# Altium schematic sheet 8-User interfaces.schdoc("8-User interfaces")
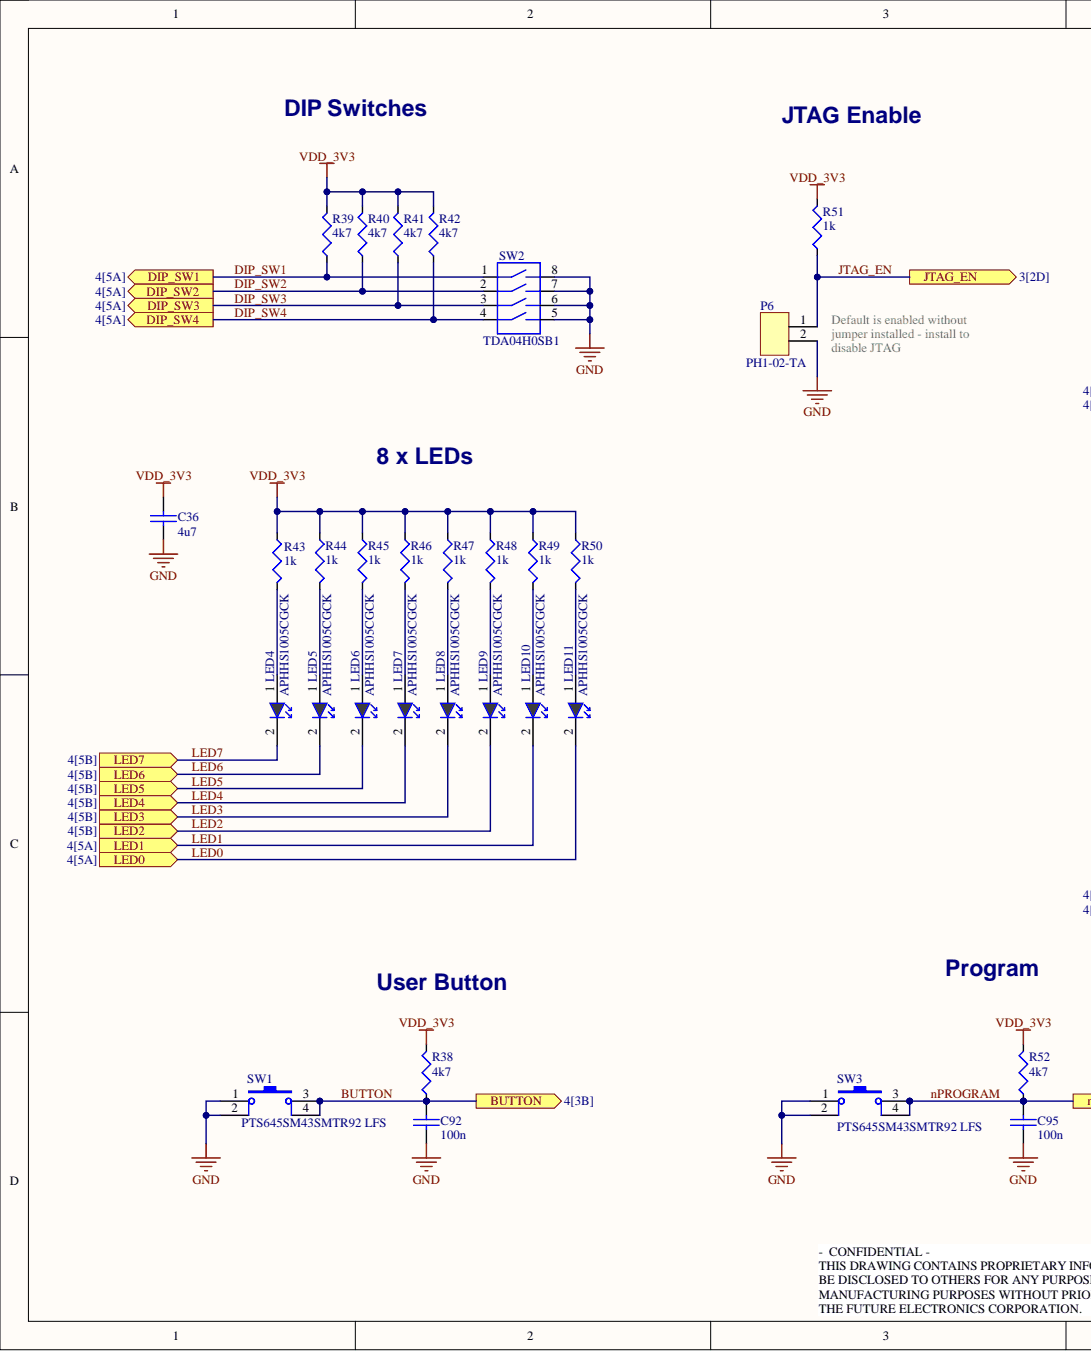
[diagram p0: 8-User interfaces.schdoc, left]
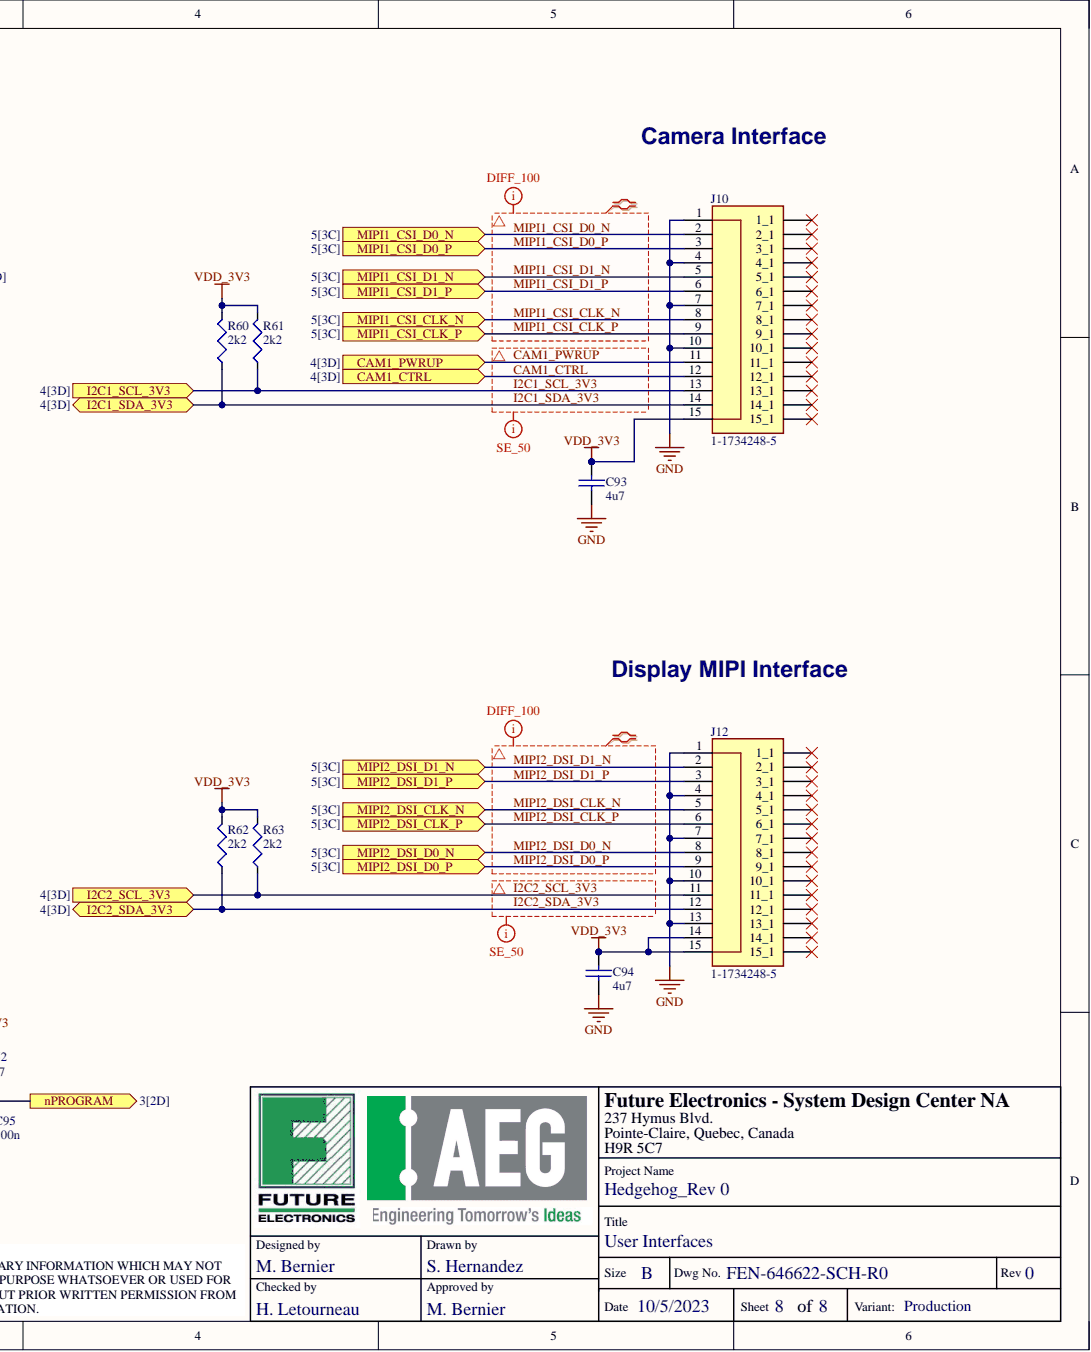
[diagram p1: 8-User interfaces.schdoc, right]

## Parts
C36  value 4u7  [0805]  (hidden comment: C-4.7uF-10V-Cer-0805)
C92  value 100n  [0402]  (hidden comment: C-100nF-50V-Cer-0402)
C93  value 4u7  [0805]  (hidden comment: C-4.7uF-10V-Cer-0805)
C94  value 4u7  [0805]  (hidden comment: C-4.7uF-10V-Cer-0805)
C95  value 100n  [0402]  (hidden comment: C-100nF-50V-Cer-0402)
J10  Future PN (TR): 1-1734248-5  [TE_1-1734248-5]  (hidden comment: J-1-1734248-5)
J12  Future PN (TR): 1-1734248-5  [TE_1-1734248-5]  (hidden comment: J-1-1734248-5)
LED4  Future PN (TR): APHHS1005CGCK  [0402-Kingbright]  (hidden comment: LED-APHHS1005CGCK)
LED5  Future PN (TR): APHHS1005CGCK  [0402-Kingbright]  (hidden comment: LED-APHHS1005CGCK)
LED6  Future PN (TR): APHHS1005CGCK  [0402-Kingbright]  (hidden comment: LED-APHHS1005CGCK)
LED7  Future PN (TR): APHHS1005CGCK  [0402-Kingbright]  (hidden comment: LED-APHHS1005CGCK)
LED8  Future PN (TR): APHHS1005CGCK  [0402-Kingbright]  (hidden comment: LED-APHHS1005CGCK)
LED9  Future PN (TR): APHHS1005CGCK  [0402-Kingbright]  (hidden comment: LED-APHHS1005CGCK)
LED10  Future PN (TR): APHHS1005CGCK  [0402-Kingbright]  (hidden comment: LED-APHHS1005CGCK)
LED11  Future PN (TR): APHHS1005CGCK  [0402-Kingbright]  (hidden comment: LED-APHHS1005CGCK)
P6  P-PH1-02-TA  MFR PN: PH1-02-TA  [HDR100-2PIN-TH]
R38  value 4k7  [0402]  (hidden comment: R-4.7k-63mW-0402)
R39  value 4k7  [0402]  (hidden comment: R-4.7k-63mW-0402)
R40  value 4k7  [0402]  (hidden comment: R-4.7k-63mW-0402)
R41  value 4k7  [0402]  (hidden comment: R-4.7k-63mW-0402)
R42  value 4k7  [0402]  (hidden comment: R-4.7k-63mW-0402)
R43  value 1k  [0402]  (hidden comment: R-1k-63mW-0402)
R44  value 1k  [0402]  (hidden comment: R-1k-63mW-0402)
R45  value 1k  [0402]  (hidden comment: R-1k-63mW-0402)
R46  value 1k  [0402]  (hidden comment: R-1k-63mW-0402)
R47  value 1k  [0402]  (hidden comment: R-1k-63mW-0402)
R48  value 1k  [0402]  (hidden comment: R-1k-63mW-0402)
R49  value 1k  [0402]  (hidden comment: R-1k-63mW-0402)
R50  value 1k  [0402]  (hidden comment: R-1k-63mW-0402)
R51  value 1k  [0402]  (hidden comment: R-1k-63mW-0402)
R52  value 4k7  [0402]  (hidden comment: R-4.7k-63mW-0402)
R60  value 2k2  [0402]  (hidden comment: R-2.2k-63mW-0402)
R61  value 2k2  [0402]  (hidden comment: R-2.2k-63mW-0402)
R62  value 2k2  [0402]  (hidden comment: R-2.2k-63mW-0402)
R63  value 2k2  [0402]  (hidden comment: R-2.2k-63mW-0402)
SW1  SW-PTS645SM43SMTR92 LFS  [SW-MOM-SMD-PTS645]  (value not drawn)
SW2  MFR PN: TDA04H0SB1  [UlMiniDip4]  (hidden comment: SW-TDA04H0SB1)
SW3  SW-PTS645SM43SMTR92 LFS  [SW-MOM-SMD-PTS645]  (value not drawn)

## Ports
BUTTON, CAM1_CTRL, CAM1_PWRUP, DIP_SW1, DIP_SW2, DIP_SW3, DIP_SW4, I2C1_SCL_3V3, I2C1_SDA_3V3, I2C2_SCL_3V3, I2C2_SDA_3V3, JTAG_EN, LED0, LED1, LED2, LED3, LED4, LED5, LED6, LED7, MIPI1_CSI_CLK_N, MIPI1_CSI_CLK_P, MIPI1_CSI_D0_N, MIPI1_CSI_D0_P, MIPI1_CSI_D1_N, MIPI1_CSI_D1_P, MIPI2_DSI_CLK_N, MIPI2_DSI_CLK_P, MIPI2_DSI_D0_N, MIPI2_DSI_D0_P, MIPI2_DSI_D1_N, MIPI2_DSI_D1_P, nPROGRAM

## Nets
GND: C36.2 C92.2 C93.2 C94.2 C95.2 J10.1 J10.4 J10.7 J10.10 J12.1 J12.4 J12.7 J12.10 J12.13 P6.2 SW1.1 SW1.2 SW2.5 SW2.6 SW2.7 SW2.8 SW3.1 SW3.2
VDD_3V3: C36.1 C93.1 C94.1 J10.15 J12.14 J12.15 R38.2 R39.2 R40.2 R41.2 R42.2 R43.1 R44.1 R45.1 R46.1 R47.1 R48.1 R49.1 R50.1 R51.2 R52.2 R60.1 R61.1 R62.1 R63.1
BUTTON: C92.1 R38.1 SW1.3 SW1.4 ; port BUTTON
CAM1_CTRL: J10.12 ; port CAM1_CTRL
CAM1_PWRUP: J10.11 ; port CAM1_PWRUP
DIP_SW1: R39.1 SW2.1 ; port DIP_SW1
DIP_SW2: R40.1 SW2.2 ; port DIP_SW2
DIP_SW3: R41.1 SW2.3 ; port DIP_SW3
DIP_SW4: R42.1 SW2.4 ; port DIP_SW4
I2C1_SCL_3V3: J10.13 R61.2 ; port I2C1_SCL_3V3
I2C1_SDA_3V3: J10.14 R60.2 ; port I2C1_SDA_3V3
I2C2_SCL_3V3: J12.11 R63.2 ; port I2C2_SCL_3V3
I2C2_SDA_3V3: J12.12 R62.2 ; port I2C2_SDA_3V3
JTAG_EN: P6.1 R51.1 ; port JTAG_EN
LED0: LED11.2 ; port LED0
LED1: LED10.2 ; port LED1
LED2: LED9.2 ; port LED2
LED3: LED8.2 ; port LED3
LED4: LED7.2 ; port LED4
LED5: LED6.2 ; port LED5
LED6: LED5.2 ; port LED6
LED7: LED4.2 ; port LED7
MIPI1_CSI_CLK_N: J10.8 ; port MIPI1_CSI_CLK_N
MIPI1_CSI_CLK_P: J10.9 ; port MIPI1_CSI_CLK_P
MIPI1_CSI_D0_N: J10.2 ; port MIPI1_CSI_D0_N
MIPI1_CSI_D0_P: J10.3 ; port MIPI1_CSI_D0_P
MIPI1_CSI_D1_N: J10.5 ; port MIPI1_CSI_D1_N
MIPI1_CSI_D1_P: J10.6 ; port MIPI1_CSI_D1_P
MIPI2_DSI_CLK_N: J12.5 ; port MIPI2_DSI_CLK_N
MIPI2_DSI_CLK_P: J12.6 ; port MIPI2_DSI_CLK_P
MIPI2_DSI_D0_N: J12.8 ; port MIPI2_DSI_D0_N
MIPI2_DSI_D0_P: J12.9 ; port MIPI2_DSI_D0_P
MIPI2_DSI_D1_N: J12.2 ; port MIPI2_DSI_D1_N
MIPI2_DSI_D1_P: J12.3 ; port MIPI2_DSI_D1_P
nPROGRAM: C95.1 R52.1 SW3.3 SW3.4 ; port nPROGRAM
NetLED4_1: LED4.1 R43.2
NetLED5_1: LED5.1 R44.2
NetLED6_1: LED6.1 R45.2
NetLED7_1: LED7.1 R46.2
NetLED8_1: LED8.1 R47.2
NetLED9_1: LED9.1 R48.2
NetLED10_1: LED10.1 R49.2
NetLED11_1: LED11.1 R50.2

Not connected: J10 1_1 2-1(2_1) 3_1 4_1 5_1 6_1 7_1 8_1 9_1 10_1 11_1 12_1 13_1 14_1 15_1; J12 1_1 2-1(2_1) 3_1 4_1 5_1 6_1 7_1 8_1 9_1 10_1 11_1 12_1 13_1 14_1 15_1

## Library data of the parts
C36 C93 C94 = C-4.7uF-10V-Cer-0805: Cap 0805 SM X7R 10V 10%; MFR: TAIYO YUDEN; MFR PN: LMK212B7475KG-T; Future PN (CT): LMK212B7475KGTR-CT; Future PN (TR): LMK212B7475KGTR; Rating: 10V 10%; Component Kind: Standard; ComponentLink1Description: Datasheet; ComponentLink1URL: https://www.yuden.co.jp/productdata/catalog/mlcc01_e.pdf; Library Name: Future_Capacitors_V1.SCHLIB; Verified: R.Brack_2020-Feb-03
C92 C95 = C-100nF-50V-Cer-0402: Cap 0402 SM X7R 50V 10%; MFR: TDK; MFR PN: C1005X7R1H104K050BB; Future PN (CT): 04025C104KATN-CT; Future PN (TR): 04025C104KATN; Rating: 50V 10%; Component Kind: Standard; ComponentLink1Description: Datasheet; ComponentLink1URL: http://images.ihscontent.net/vipimages/VipMasterIC/IC/TDKC/TDKC-S-A0000443042/TDKC-S-A0000443042-1.pdf; Library Name: Future_Capacitors_V1.SCHLIB; Verified: AD042616
J10 J12 = J-1-1734248-5: Connector FPC Vert SM 1mm pitch; MFR: TE; Future PN (CT): TBD; Rating: 1x15, 1mm pitch; VModel: MMDDYY; Component Kind: Standard; ComponentLink1Description: Datasheet; ComponentLink1URL: https://www.te.com/commerce/DocumentDelivery/DDEController?Action=srchrtrv&DocNm=1734248&DocType=Customer+Drawing&DocLang=English; MFR PN: 1-1734248-5; Created by: CZ050922; Library Name: Hedgehog_Rev0.SchLib
LED4-LED11 = LED-APHHS1005CGCK: LED Green AlGaInP 0402 SM 2.1-2.5Vf 30mA 574nm-pk 570nm-d 20mcd; MFR: KINGBRIGHT; Future PN (CT): APHHS1005CGCK-CT; Rating: 2.1Vf 20mA 75mW 570nm 50mcd; Component Kind: Standard; ComponentLink1Description: Datasheet; ComponentLink1URL: http://www.kingbrightusa.com/images/catalog/SPEC/APHHS1005CGCK.pdf; Library Name: Future_Opto_V1.SchLib; MFR PN: APHHS1005CGCK; Value: GREEN; Created by: R.Brack_2020-Mar-02
P6 = P-PH1-02-TA: Connector 1Row Vert TH 1x2 2.54mm Pin Header; MFR: ADAMTECH; Future PN (CT): NSH-02SB-S2-T; Future PN (TR): TBD; VModel: MMDDYY; Component Kind: Standard; ComponentLink1Description: Datasheet; ComponentLink1URL: http://images.ihscontent.net/vipimages/VipMasterIC/IC/ADMT/ADMTS02206/ADMTS02206-1.pdf; Library Name: Future_Connectors_V1.SCHLIB; Rating: 1x2 2.54mm; Verified: AD072915
R38-R42 R52 = R-4.7k-63mW-0402: Res 0402 SM 63mW 1% 100ppm; MFR: YAGEO; MFR PN: RC0402FR-074K7L; Future PN (CT): CR0402-4701FTR-CT; Future PN (TR): CR0402-4701FTR; Rating: 63mW 1%; Component Kind: Standard; ComponentLink1Description: Datasheet; ComponentLink1URL: http://www.yageo.com/documents/recent/PYu-RC0402_51_RoHS_L_6.pdf; Library Name: Future_Resistors_V1.SCHLIB
R43-R51 = R-1k-63mW-0402: Res 0402 SM 63mW 1% 100ppm; MFR: YAGEO; MFR PN: RC0402FR-071KL; Future PN (CT): CR0402-1001FTR-CT; Future PN (TR): CR0402-1001FTR; Rating: 63mW 1%; Component Kind: Standard; ComponentLink1Description: Datasheet; ComponentLink1URL: https://www.yageo.com/upload/media/product/products/datasheet/rchip/PYu-RC_Group_51_RoHS_L_10.pdf; Library Name: Future_Resistors_V1.SCHLIB
R60-R63 = R-2.2k-63mW-0402: Res 0402 SM 63mW 1% 100ppm; MFR: YAGEO; MFR PN: RC0402FR-072K2L; Future PN (CT): CR0402-2201FTR-CT; Future PN (TR): CR0402-2201FTR; Rating: 63mW 1%; Component Kind: Standard; ComponentLink1Description: Datasheet; ComponentLink1URL: https://www.yageo.com/upload/media/product/products/datasheet/rchip/PYu-RC_Group_51_RoHS_L_10.pdf; Library Name: Future_Resistors_V1.SCHLIB
SW1 SW3 = SW-PTS645SM43SMTR92 LFS: Switch Mom SPST-NO 6.0x6.0 SM 12Vdc 50mA; MFR: C&K; Future PN (CT): TBD; Component Kind: Standard; ComponentLink1Description: Datasheet; ComponentLink1URL: https://www.ckswitches.com/media/1471/pts645.pdf; Future PN (TR): TBD; MFR PN: PTS645SM43SMTR92 LFS; Rating: 12Vdc 50mA; Verified: TBD; Library Name: Hedgehog_Rev0.SchLib
SW2 = SW-TDA04H0SB1: Switch Slide 4-SPST UlMiniDip4 SM 24Vdc 25mA 100mOhm; MFR: C&K; Future PN (CT): TDA04H0SK1R-CT; Future PN (TR): TDA04H0SK1R; VModel: MMDDYY; Component Kind: Standard; ComponentLink1Description: Datasheet; ComponentLink1URL: http://www1.futureelectronics.com/doc/C%20&%20K%20COMPONENTS/TDA04H0SB1.pdf; Rating: 24Vdc 25mA; Library Name: Future_Emech_V1.SchLib; Verified: AD070615
